# Altium schematic sheet V853_SYSTEM.SchDoc(V853_SYSTEM)
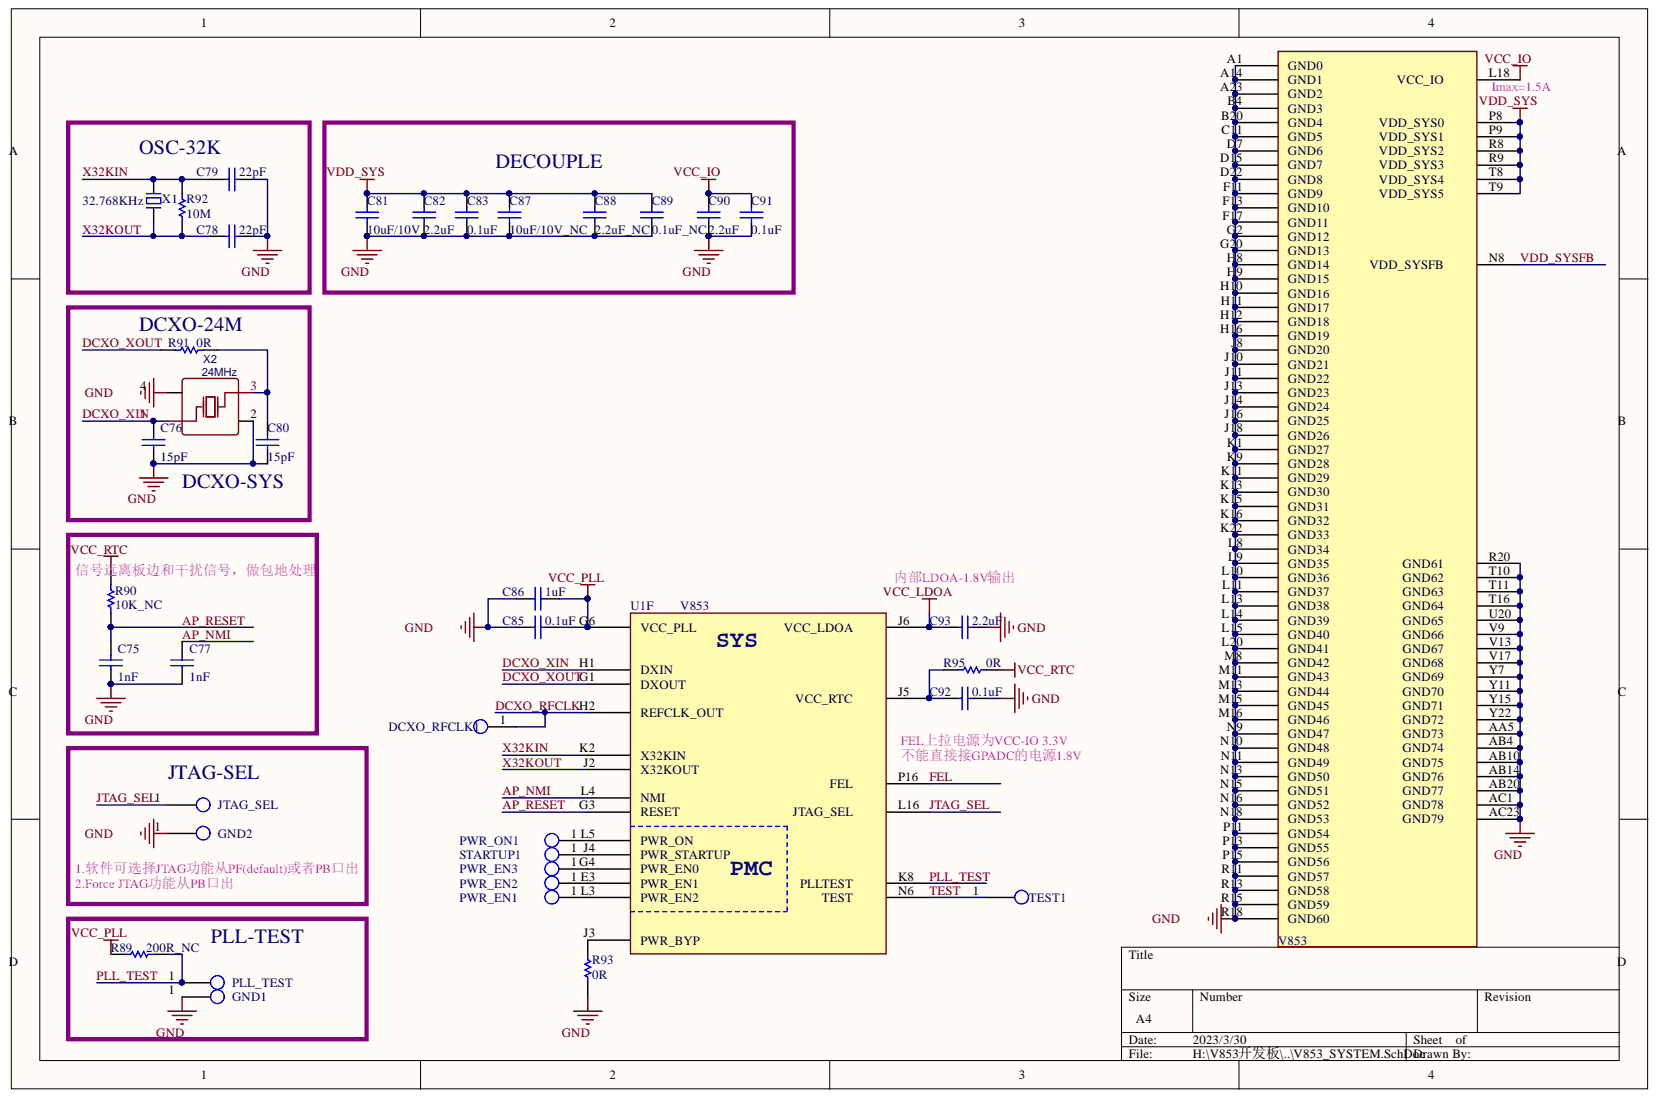
[diagram p0: V853_SYSTEM.SchDoc]

## Parts
C75  1nF  [C0201]
C76  15pF  [C0201]
C77  1nF  [C0201]
C78  22pF  [C0201]
C79  22pF  [C0201]
C80  15pF  [C0201]
C81  10uF/10V  [0402]
C82  2.2uF  [0402]
C83  0.1uF  [C0201]
C85  0.1uF  [C0201]
C86  1uF  [0402]
C87  10uF/10V_NC  [0402]
C88  2.2uF_NC  [0402]
C89  0.1uF_NC  [C0201]
C90  2.2uF  [0402]
C91  0.1uF  [C0201]
C92  0.1uF  [C0201]
C93  2.2uF  [0402]
DCXO_RFCLK1  Value  [TP_0.5MM]  (value not drawn)
GND1  Value  [TP_0.5MM]  (value not drawn)
GND2  Value  [TP_0.5MM]  (value not drawn)
JTAG_SEL  Value  [TP_0.5MM]  (value not drawn)
PLL_TEST  Value  [TP_0.5MM]  (value not drawn)
PWR_EN1  Value  [TP_0.5MM]  (value not drawn)
PWR_EN2  Value  [TP_0.5MM]  (value not drawn)
PWR_EN3  Value  [TP_0.5MM]  (value not drawn)
PWR_ON1  Value  [TP_0.5MM]  (value not drawn)
R89  200R_NC  [R0201]
R90  10K_NC  [R0201]
R91  0R  [R0201]
R92  10M  [R0201]
R93  0R  [0402]
R95  0R  [R0201]
STARTUP1  Value  [TP_0.5MM]  (value not drawn)
TEST1  Value  [TP_0.5MM]  (value not drawn)
U1  V853  (parts F,H)
X1  32.768KHz  [OSC-SMD_L3.2-W1.5]
X2  24MHz  [CRYSTAL-SMD_4P-L2.0-W1.6-BL-A]

## Nets
GND: C75.2 C76.2 C77.2 C78.2 C79.2 C80.2 C81.1 C82.2 C83.2 C85.1 C86.1 C87.1 C88.2 C89.2 C90.2 C91.2 C92.2 C93.2 GND1.1 GND2.1 R93.2 U1.A1(GND0) U1.A14(GND1) U1.A23(GND2) U1.AA5 U1.AB4 U1.AB10 U1.AB14 U1.AB20 U1.AC1 U1.AC23 U1.B4(GND3) U1.B20(GND4) U1.C11(GND5) U1.D7(GND6) U1.D15(GND7) U1.D22(GND8) U1.F11(GND9) U1.F13(GND10) U1.F17(GND11) U1.G2(GND12) U1.G20(GND13) U1.H8(GND14) U1.H9(GND15) U1.H10(GND16) U1.H11(GND17) U1.H12(GND18) U1.H16(GND19) U1.J8(GND20) U1.J10(GND21) U1.J11(GND22) U1.J13(GND23) U1.J14(GND24) U1.J16(GND25) U1.J18(GND26) U1.K1(GND27) U1.K9(GND28) U1.K11(GND29) U1.K13(GND30) U1.K15(GND31) U1.K16(GND32) U1.K22(GND33) U1.L8(GND34) U1.L9(GND35) U1.L10(GND36) U1.L11(GND37) U1.L13(GND38) U1.L14(GND39) U1.L15(GND40) U1.L20(GND41) U1.M8(GND42) U1.M11(GND43) U1.M13(GND44) U1.M15(GND45) U1.M16(GND46) U1.N9(GND47) U1.N10(GND48) U1.N11(GND49) U1.N13(GND50) U1.N15(GND51) U1.N16(GND52) U1.N18(GND53) U1.P11(GND54) U1.P13(GND55) U1.P15(GND56) U1.R11(GND57) U1.R13(GND58) U1.R15(GND59) U1.R18(GND60) U1.R20 U1.T10 U1.T11 U1.T16 U1.U20 U1.V9 U1.V13 U1.V17 U1.Y7 U1.Y11 U1.Y15 U1.Y22 X2.2 X2.4
VCC_IO: C90.1 C91.1 U1.L18
VCC_LDOA: C93.1 U1.J6
VCC_PLL: C85.2 C86.2 R89.1 U1.G6(VCC_PLL)
VCC_RTC: R90.1 R95.2
VDD_SYS: C81.2 C82.1 C83.1 C87.2 C88.1 C89.1 U1.P8 U1.P9 U1.R8 U1.R9 U1.T8 U1.T9
AP_NMI: C77.1 U1.L4(NMI)
AP_RESET: C75.1 R90.2 U1.G3(RESET)
DCXO_RFCLK: DCXO_RFCLK1.1 U1.H2(REFCLK_OUT)
DCXO_XIN: C76.1 U1.H1(DXIN) X2.1
DCXO_XOUT: R91.2 U1.G1(DXOUT)
FEL: U1.P16
JTAG_SEL: JTAG_SEL.1 U1.L16
PLL_TEST: PLL_TEST.1 R89.2 U1.K8
TEST: TEST1.1 U1.N6
VDD_SYSFB: U1.N8
X32KIN: C79.1 R92.1 U1.K2(X32KIN) X1.2
X32KOUT: C78.1 R92.2 U1.J2(X32KOUT) X1.1
NetC80_1: C80.1 R91.1 X2.3
NetC92_1: C92.1 R95.1 U1.J5
NetPWR_EN1_1: PWR_EN1.1 U1.L3(PWR_EN2)
NetPWR_EN2_1: PWR_EN2.1 U1.E3(PWR_EN1)
NetPWR_EN3_1: PWR_EN3.1 U1.G4(PWR_EN0)
NetPWR_ON1_1: PWR_ON1.1 U1.L5(PWR_ON)
NetR93_1: R93.1 U1.J3(PWR_BYP)
NetSTARTUP1_1: STARTUP1.1 U1.J4(PWR_STARTUP)

## Library data of the parts
C75-C80 C85 C86 C92 C93 = Cap: Capacitor; PartNumber: CAP18PF; Component Kind: Standard; LatestRevisionDate: 17-Jul-2002; LatestRevisionNote: Re-released for DXP Platform.; Library Name: Miscellaneous Devices.IntLib; PackageReference: 0402; Published: 8-Jun-2000; Signal Integrity: Cap; Simulation: CAP; Publisher: Altium Limited
  C75 C77: Value: 1nF
  C76 C80: Value: 15pF
  C78 C79: Value: 22pF
  C85 C92: Value: 0.1uF
  C86: Value: 1uF
  C93: Value: 2.2uF
C81-C83 C87-C91 = Cap: Capacitor; PartNumber: CAP100NF; Component Kind: Standard; LatestRevisionDate: 17-Jul-2002; LatestRevisionNote: Re-released for DXP Platform.; Library Name: Miscellaneous Devices.IntLib; PackageReference: 0402; Published: 8-Jun-2000; Signal Integrity: Cap; Simulation: CAP; Publisher: Altium Limited
  C81: Value: 10uF/10V
  C82 C90: Value: 2.2uF
  C83 C91: Value: 0.1uF
  C87: Value: 10uF/10V_NC
  C88: Value: 2.2uF_NC
  C89: Value: 0.1uF_NC
DCXO_RFCLK1 GND1 GND2 JTAG_SEL PLL_TEST PWR_EN1-PWR_EN3 PWR_ON1 STARTUP1 TEST1 = TP: Component Kind: Standard; Library Name: HM2.SchLib
R89-R93 R95 = Res1: Resistor; PartNumber: RES2.2K; Component Kind: Standard; LatestRevisionDate: 17-Jul-2002; LatestRevisionNote: Re-released for DXP Platform.; Library Name: Miscellaneous Devices.IntLib; PackageReference: 0402; Published: 8-Jun-2000; Signal Integrity: Res; Simulation: RESISTOR; Publisher: Altium Limited
  R89: Value: 200R_NC
  R90: Value: 10K_NC
  R91 R93 R95: Value: 0R
  R92: Value: 10M
U1 = V853_0621: PCB Footprint: BGA318P50B1200_1200H113; SPLIT_INST: TRUE; SWAP_INFO: (S1+S2+S3+S4+S5+S6+S7+S8+S9); Component Kind: Standard; Library Name: V853_CORE_20230224.SCHLIB
X1 = XTAL-2P: 2脚无源脚晶振; 版权归属: 源创客; 负载电容: CL20pF; BOM名称: 2脚无源脚晶振; Component Kind: Standard; Library Name: STM32F407_CORE_BOARD.SCHLIB; 学习资源: www.ooocke.com
X2 = 24MHz: BOM_Manufacturer: JGHC; Contributor: LCSC; nameAlias: Frequency; VALUE: TAXM24M4ZDBCCT2T; BOM_Supplier: LCSC; Component Kind: Standard; JLC NO: C388793; spicePre: X
